# Altium schematic sheet RF_and_GPS.SchDoc
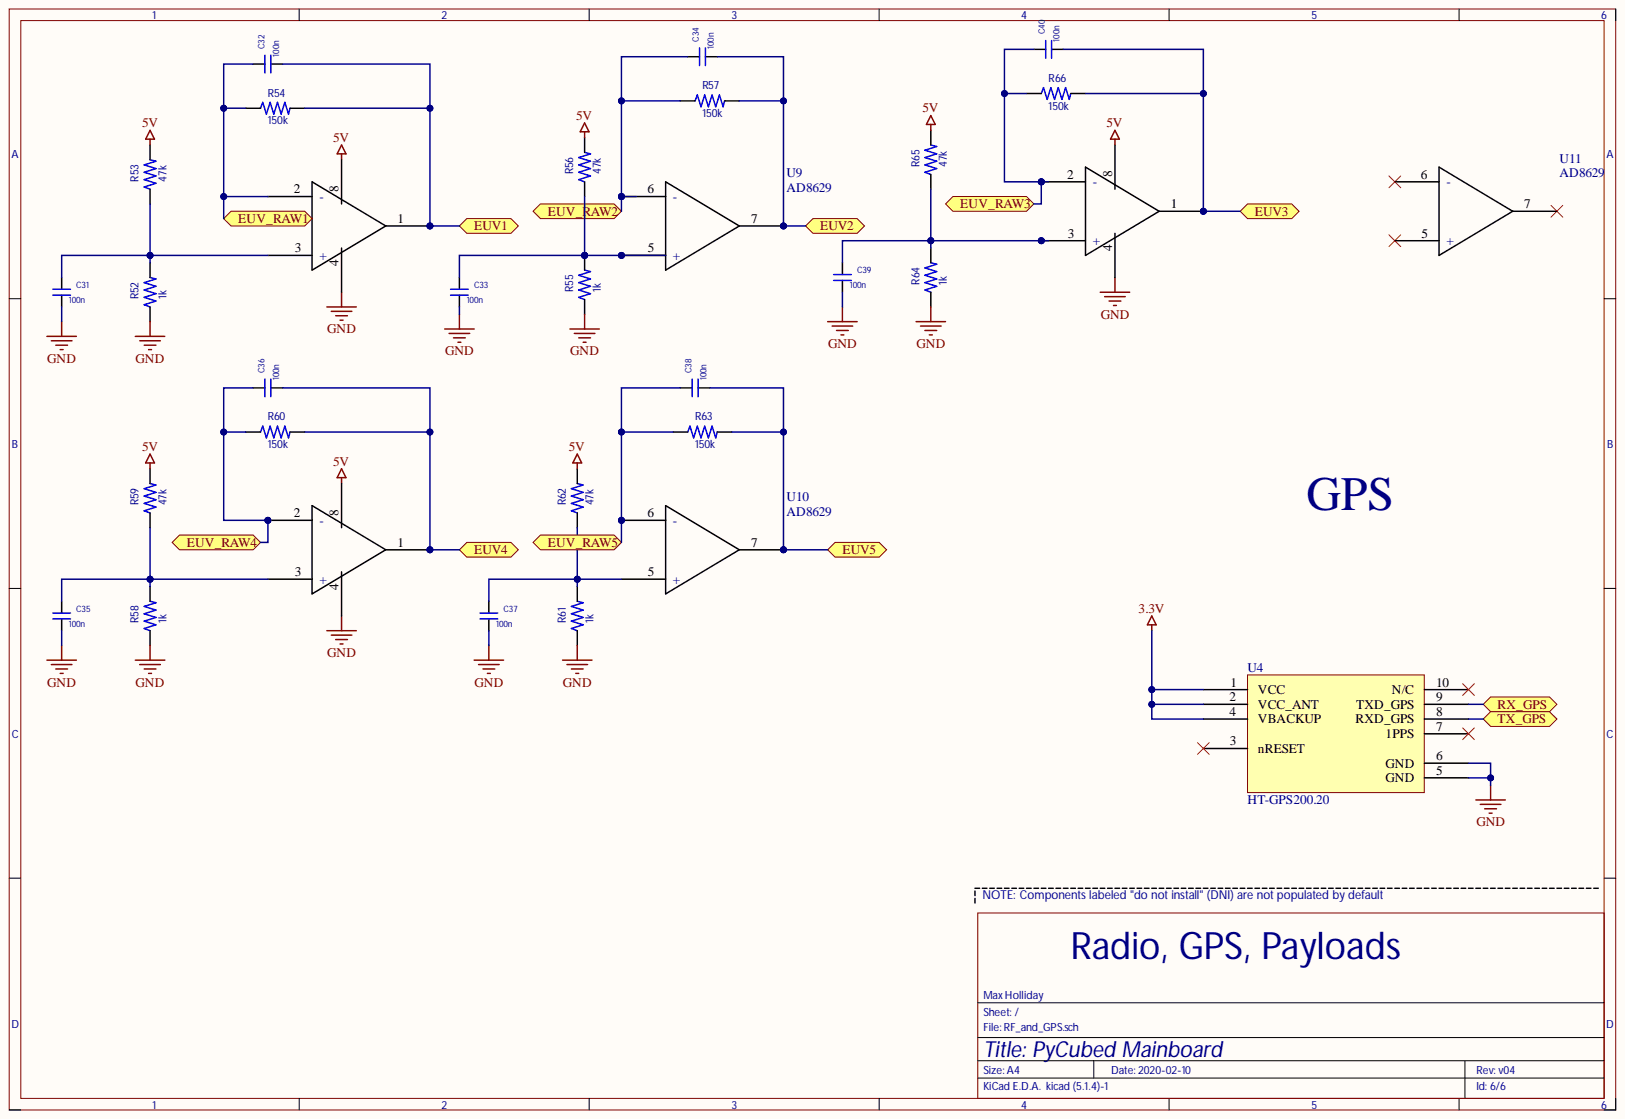
[diagram p0: RF_and_GPS.SchDoc]

## Parts
C31  100n  [Capacitor_SMD:C_0603_1608Metric]
C32  value 100n  [Capacitor_SMD:C_0603_1608Metric]  (hidden comment: 10p)
C33  100n  [Capacitor_SMD:C_0603_1608Metric]
C34  value 100n  [Capacitor_SMD:C_0603_1608Metric]  (hidden comment: 10p)
C35  100n  [Capacitor_SMD:C_0603_1608Metric]
C36  value 100n  [Capacitor_SMD:C_0603_1608Metric]  (hidden comment: 10p)
C37  100n  [Capacitor_SMD:C_0603_1608Metric]
C38  value 100n  [Capacitor_SMD:C_0603_1608Metric]  (hidden comment: 10p)
C39  100n  [Capacitor_SMD:C_0603_1608Metric]
C40  value 100n  [Capacitor_SMD:C_0603_1608Metric]  (hidden comment: 10p)
R52  1k  [Resistor_SMD:R_0603_1608Metric]
R53  47k  [Resistor_SMD:R_0603_1608Metric]
R54  150k  [Resistor_SMD:R_0603_1608Metric]
R55  1k  [Resistor_SMD:R_0603_1608Metric]
R56  47k  [Resistor_SMD:R_0603_1608Metric]
R57  150k  [Resistor_SMD:R_0603_1608Metric]
R58  1k  [Resistor_SMD:R_0603_1608Metric]
R59  47k  [Resistor_SMD:R_0603_1608Metric]
R60  150k  [Resistor_SMD:R_0603_1608Metric]
R61  1k  [Resistor_SMD:R_0603_1608Metric]
R62  47k  [Resistor_SMD:R_0603_1608Metric]
R63  150k  [Resistor_SMD:R_0603_1608Metric]
R64  1k  [Resistor_SMD:R_0603_1608Metric]
R65  47k  [Resistor_SMD:R_0603_1608Metric]
R66  150k  [Resistor_SMD:R_0603_1608Metric]
U4  HT-GPS200.20  [HT-GPS200.20]
U9  AD8629  [soic-8]
U10  AD8629  [soic-8]
U11  AD8629  [soic-8]

## Ports
EUV1, EUV2, EUV3, EUV4, EUV5, EUV_RAW1, EUV_RAW2, EUV_RAW3, EUV_RAW4, EUV_RAW5, RX_GPS, TX_GPS

## Nets
3.3V: U4.1(VCC) U4.2(VCC_ANT) U4.4(VBACKUP)
5V: R53.2 R56.2 R59.2 R62.2 R65.2 U9.8 U10.8 U11.8
GND: C31.2 C33.2 C35.2 C37.2 C39.2 R52.1 R55.1 R58.1 R61.1 R64.1 U4.5(GND) U4.6(GND) U9.4 U10.4 U11.4
EUV1: C32.2 R54.2 U9.1 ; port EUV1
EUV2: C34.2 R57.2 U9.7 ; port EUV2
EUV3: C40.2 R66.2 U11.1 ; port EUV3
EUV4: C36.2 R60.2 U10.1 ; port EUV4
EUV5: C38.2 R63.2 U10.7 ; port EUV5
EUV_RAW1: C32.1 R54.1 U9.2(-) ; port EUV_RAW1
EUV_RAW2: C34.1 R57.1 U9.6 ; port EUV_RAW2
EUV_RAW3: C40.1 R66.1 U11.2(-) ; port EUV_RAW3
EUV_RAW4: C36.1 R60.1 U10.2(-) ; port EUV_RAW4
EUV_RAW5: C38.1 R63.1 U10.6 ; port EUV_RAW5
RX_GPS: U4.9(TXD_GPS) ; port RX_GPS
TX_GPS: U4.8(RXD_GPS) ; port TX_GPS
NetC31_1: C31.1 R52.2 R53.1 U9.3(+)
NetC33_1: C33.1 R55.2 R56.1 U9.5
NetC35_1: C35.1 R58.2 R59.1 U10.3(+)
NetC37_1: C37.1 R61.2 R62.1 U10.5
NetC39_1: C39.1 R64.2 R65.1 U11.3(+)

Not connected: U4 3(nRESET) 7(1PPS) 10(N/C); U11 5 6 7

## Library data of the parts
C31 C33 C35 C37 C39 = C_Small_1206_100n: MPN: GRM31C5C1E104JA01L; Component Kind: Standard; Library Name: mainboard_1.SCHLIB; Supplier 1: Arrow Electronics; Supplier Part Number 1: GRM31C5C1E104JA01L; Value: 100n
C32 C34 C36 C38 C40 = C_Small_0805_10p: MPN: C0805C100J5GACTU; Component Kind: Standard; Library Name: mainboard_1.SCHLIB; Supplier 1: Arrow Electronics; Supplier Part Number 1: C0805C100J5GACTU
R52 R55 R58 R61 R64 = R-US_R0805_1: MPN: RN73C2A1K0BTDF; Component Kind: Standard; Library Name: mainboard_1.SCHLIB; Supplier 1: RSComponents; Supplier Part Number 1: 6790982; Value: 1k
R53 R56 R59 R62 R65 = R-US_R0805_2: MPN: ERJ-6GEYJ473V; Component Kind: Standard; Library Name: mainboard_1.SCHLIB; Supplier 1: Newark; Supplier Part Number 1: 12AC1198; Value: 47k
R54 R57 R60 R63 R66 = R-US_R0805_3: MPN: ERJ-6ENF1503V; Component Kind: Standard; Library Name: mainboard_1.SCHLIB; Supplier 1: Newark; Supplier Part Number 1: 64R5382; Value: 150k
U4 = HT-GPS200.20: HT-GPS200.20 GNSS module; Component Kind: Standard; Library Name: mainboard_1.SCHLIB
U9-U11 = AD8629: MPN: AD8629ARZ; Component Kind: Standard; Library Name: mainboard_1.SCHLIB
